# Altium schematic sheet E-CAN.SchDoc(E-CAN)
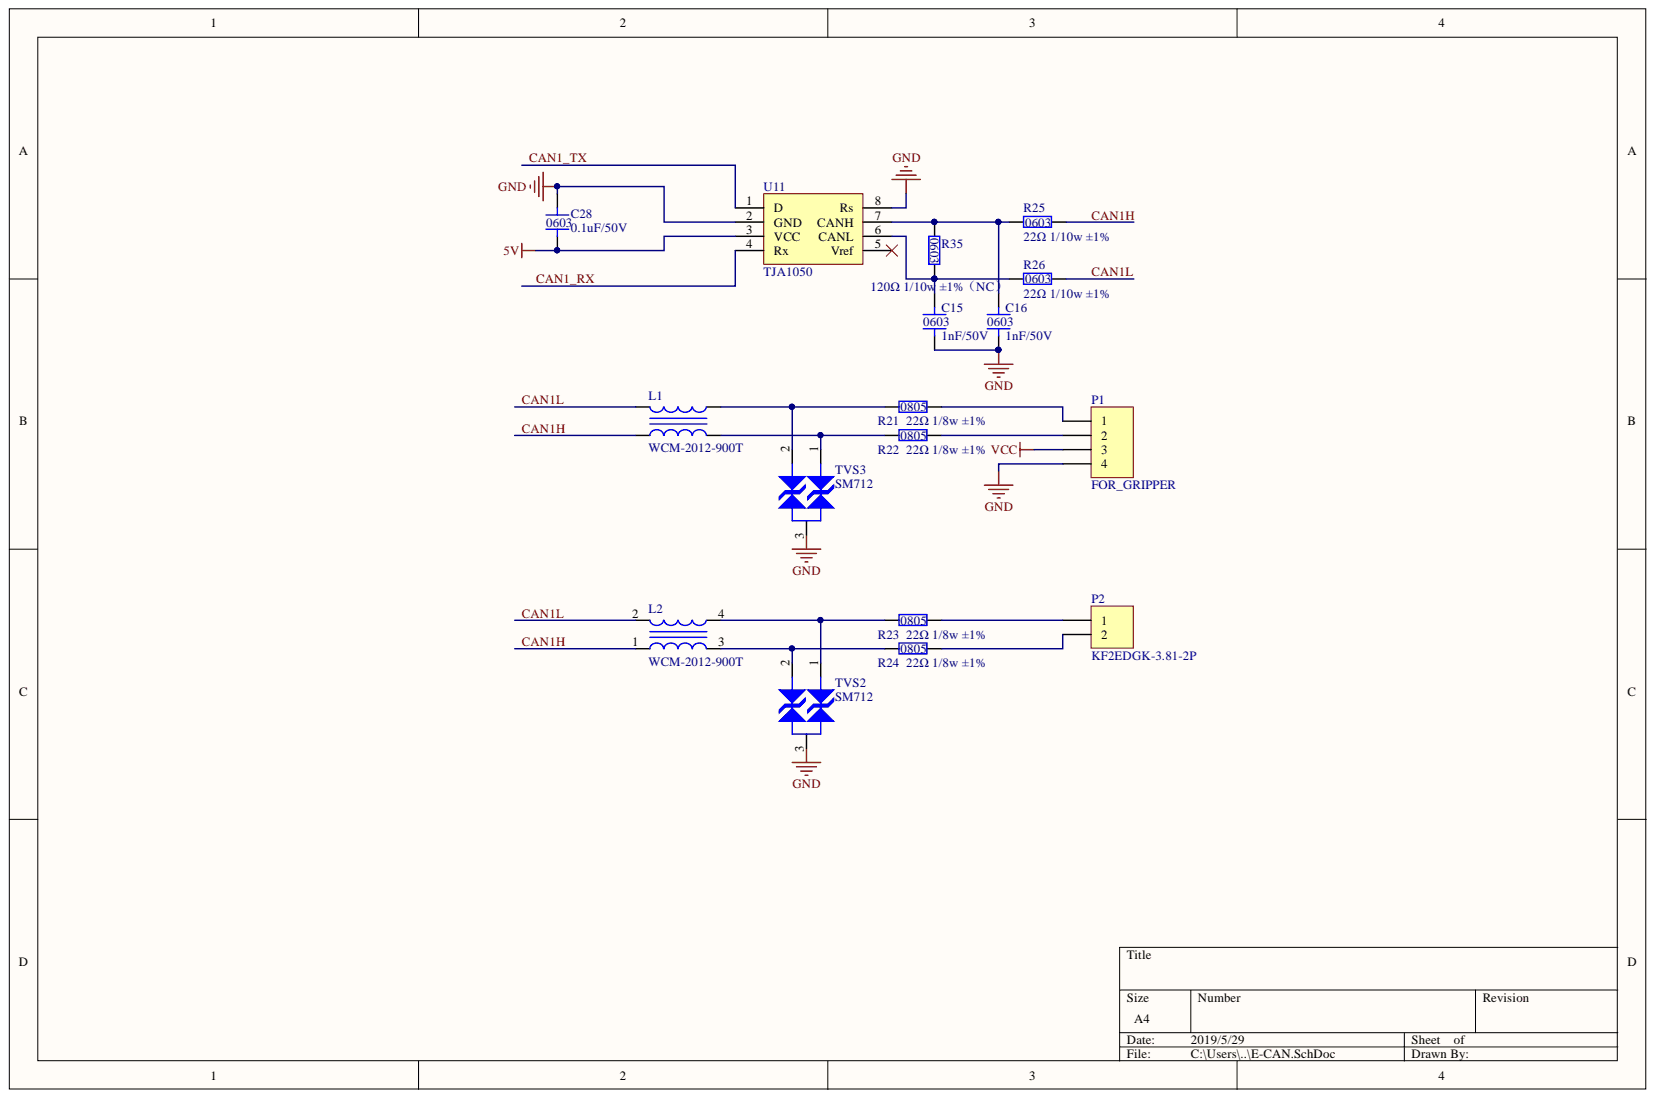
[diagram p0: E-CAN.SchDoc]

## Parts
C15  1nF/50V  [C-0603]
C16  1nF/50V  [C-0603]
C28  0.1uF/50V  [C-0603]
L1  WCM-2012-900T  [贴片共模电感-2520]
L2  WCM-2012-900T  [贴片共模电感-2520]
P1  FOR_GRIPPER  [M8-4P-A]
P2  KF2EDGK-3.81-2P  [KF2EDG3.81-2P]
R21  22Ω 1/8w ±1%  [R-0805]
R22  22Ω 1/8w ±1%  [R-0805]
R23  22Ω 1/8w ±1%  [R-0805]
R24  22Ω 1/8w ±1%  [R-0805]
R25  22Ω 1/10w ±1%  [R-0603]
R26  22Ω 1/10w ±1%  [R-0603]
R35  120Ω 1/10w ±1%（NC）  [R-0603]
TVS2  SM712  [SOT-23]
TVS3  SM712  [SOT-23]
U11  TJA1050  [SN65HVD230-3D]

## Nets
5V: C28.1 U11.3(VCC)
GND: C15.1 C16.1 C28.2 P1.4 TVS2.3 TVS3.3 U11.2(GND) U11.8(Rs)
VCC: P1.3
CAN1_RX: U11.4(Rx)
CAN1_TX: U11.1(D)
CAN1H: L1.1 L2.1 R25.2
CAN1L: L1.2 L2.2 R26.2
NetC15_2: C15.2 R26.1 R35.2 U11.6(CANL)
NetC16_2: C16.2 R25.1 R35.1 U11.7(CANH)
NetL1_3: L1.3 R22.1 TVS3.1
NetL1_4: L1.4 R21.1 TVS3.2
NetL2_3: L2.3 R24.1 TVS2.2
NetL2_4: L2.4 R23.1 TVS2.1
NetP1_1: P1.1 R21.2
NetP1_2: P1.2 R22.2
NetP2_1: P2.1 R23.2
NetP2_2: P2.2 R24.2

Not connected: U11 5(Vref)

## Library data of the parts
C15 C16 C28 = 10uF/50V: Component Kind: Standard; Library Name: dahuan.SchLib
L1 L2 = INDUCTOR C: Component Kind: Standard; Library Name: DH-SchLib.SchLib
P1 = Header 4: Header, 4-Pin; Component Kind: Standard; LatestRevisionDate: 17-Jul-2002; LatestRevisionNote: Re-released for DXP Platform.; Library Name: Miscellaneous Connectors.IntLib; Signal Integrity: Connector; Publisher: Altium Limited
P2 = Header 2: Header, 2-Pin; Component Kind: Standard; LatestRevisionDate: 17-Jul-2002; LatestRevisionNote: Re-released for DXP Platform.; Library Name: Miscellaneous Connectors.IntLib; Signal Integrity: Connector; Publisher: Altium Limited
R21-R24 = 3kΩ 1/10w ±1%: Component Kind: Standard; Library Name: dahuan.SchLib
R25 R26 R35 = 2kΩ 1/10w ±1%: Component Kind: Standard; Library Name: dahuan.SchLib
TVS2 TVS3 = ESD_DUAL: Component Kind: Standard; Library Name: dahuan.SchLib
U11 = SN: CAN芯片; Component Kind: Standard; Library Name: fszhang.SchLib
